# Altium schematic sheet Connectors.SchDoc(Connectors)
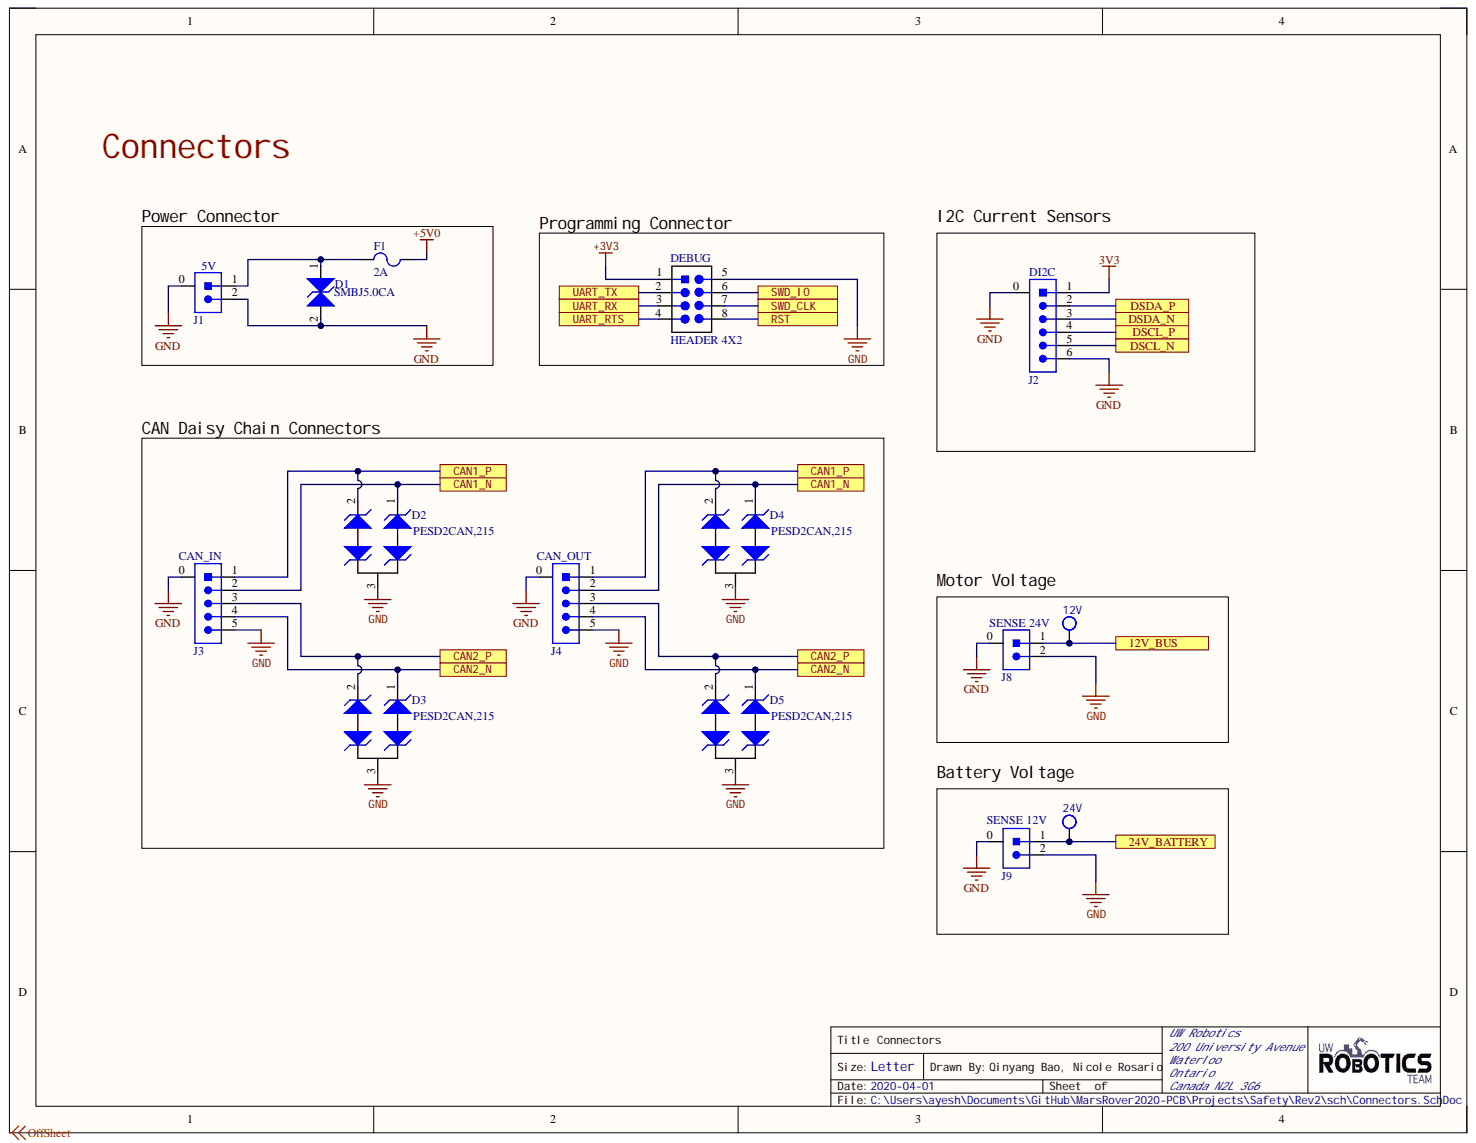
[diagram p0: Connectors.SchDoc]

## Parts
12V  TESTPOINT_LOOP  [TESTPOINT_LOOP]  (value not drawn)
24V  TESTPOINT_LOOP  [TESTPOINT_LOOP]  (value not drawn)
D1  Manufacturer Part Number 1: SMBJ5.0CA  [TVS_DIODE_BIDIRECTIONAL]  (hidden comment: TVS_DIODE_BIDIRECTIONAL)
D2  Manufacturer Part Number: PESD2CAN,215  [NXP-SOT323-3]  (hidden comment: TVS_DIODE_CAN)
D3  Manufacturer Part Number: PESD2CAN,215  [NXP-SOT323-3]  (hidden comment: TVS_DIODE_CAN)
D4  Manufacturer Part Number: PESD2CAN,215  [NXP-SOT323-3]  (hidden comment: TVS_DIODE_CAN)
D5  Manufacturer Part Number: PESD2CAN,215  [NXP-SOT323-3]  (hidden comment: TVS_DIODE_CAN)
DEBUG  HEADER 4X2  [HEADER_2X4]
F1  Current Rating: 2A  [FUSE_0603]  (hidden comment: FUSE_2A_0603)
J1  5V  [MOLEX-5024940270]
J2  DI2C  [MOLEX-5024940670]
J3  CAN_IN  [MOLEX-5025850570]
J4  CAN_OUT  [MOLEX-5025850570]
J8  SENSE 24V  [MOLEX-5024940270]
J9  SENSE 12V  [MOLEX-5024940270]

## Ports
12V_BUS, 24V_BATTERY, CAN1_N, CAN1_P, CAN2_N, CAN2_P, DSCL_N, DSCL_P, DSDA_N, DSDA_P, SWD_CLK, SWD_IO, UART_RTS, UART_RX, UART_TX, ~{RST}

## Nets
3V3: DEBUG.1 J2.1
+5V0: F1.2
GND: D1.2 D2.3 D3.3 D4.3 D5.3 DEBUG.5 J1.0 J1.2 J2.0 J2.6 J3.0 J3.5 J4.0 J4.5 J8.0 J8.2 J9.0 J9.2
12V_BUS: 12V.1 J8.1 ; port 12V_BUS
24V_BATTERY: 24V.1 J9.1 ; port 24V_BATTERY
~{RST}: DEBUG.8 ; port ~{RST}
CAN1_N: D2.1 D4.1 J3.2 J4.2 ; port CAN1_N
CAN1_P: D2.2 D4.2 J3.1 J4.1 ; port CAN1_P
CAN2_N: D3.1 D5.1 J3.4 J4.4 ; port CAN2_N
CAN2_P: D3.2 D5.2 J3.3 J4.3 ; port CAN2_P
DSCL_N: J2.5 ; port DSCL_N
DSCL_P: J2.4 ; port DSCL_P
DSDA_N: J2.3 ; port DSDA_N
DSDA_P: J2.2 ; port DSDA_P
SWD_CLK: DEBUG.7 ; port SWD_CLK
SWD_IO: DEBUG.6 ; port SWD_IO
UART_RTS: DEBUG.4 ; port UART_RTS
UART_RX: DEBUG.3 ; port UART_RX
UART_TX: DEBUG.2 ; port UART_TX
NetD1_1: D1.1 F1.1 J1.1
OffSheet:

## Library data of the parts
12V 24V = TESTPOINT_LOOP: Loop testpoint through-hole pad; Manufacturer: Keystone Electronics; Manufacturer Part Number 1: 5002; Component Kind: Standard; Supplier 1: Digi-Key; Supplier Part Number 1: 36-5002-ND; Library Name: UWRT_Schematic_Library_2020.SchLib
D1 = TVS_DIODE_BIDIRECTIONAL: TVS DIODE 5V 9.2V DO214AA; Manufacturer: Nexperia USA Inc.; Manufacturer 1: Littelfuse; Breakdown Voltage: 6.4V; Element Configuration: Single; ESD Protection: Yes; Leakage Current: 1.6mA; Max Breakdown Voltage: 7V; Max Power Dissipation: 5W; Max Surge Current: 65.3A; Number of Bidirectional Channels: 1; Peak Pulse Power: 600W; Power Line Protection: No; Test Current: 10mA; Case/Package: SMB; Clamping Voltage: 9.2V; Component Kind: Standard; ComponentLink1Description: Datasheet; ComponentLink1URL: https://www.littelfuse.com/~/media/electronics/datasheets/tvs_diodes/littelfuse_tvs_diode_smbj_datasheet.pdf.pdf; Composition: Zener; Direction: Bidirectional; Height: 0.096inch; Lead Free: Lead Free; Length: 0.179inch; Max Operating Temperature: 150°C; Max Reverse Leakage Current: 1.6mA; Min Breakdown Voltage: 6.4V; Min Operating Temperature: -65°C; Mount: Surface Mount; MPN: SMBJ5.0CA; Number of Channels: 1; Number of Elements: 1; Number of Pins: 2; Operating Supply Voltage: 5V; Package: SC-70, SOT-323; Package Quantity: 3000; Packaging: Tape and Reel; Peak Pulse Current: 65.3A; Polarity: Bidirectional; Power Dissipation: 600W; Pricing 1: 1=0.39000, 10=0.31300, 25=0.28640, 100=0.17980, 250=0.17784, 500=0.16650, 1000=0.11322 (USD); Radiation Hardening: No; REACH SVHC: No SVHC; Reverse Breakdown Voltage: 6.4V; Reverse Standoff Voltage: 5V; Stock 1: 23233; Supplier 1: Digi-Key; Supplier Part Number 1: SMBJ5.0CALFCT-ND; Termination: SMD/SMT; Voltage Rating (DC): 5V; Width: 0.155inch; Library Name: UWRT_Schematic_Library_2020.SchLib
D2-D5 = TVS_DIODE_CAN: TVS DIODE 24V 41V SOT323-3; Manufacturer: Nexperia USA Inc.; Component Kind: Standard; ComponentLink1Description: Datasheet; ComponentLink1URL: https://assets.nexperia.com/documents/data-sheet/PESD1CAN-U.pdf; Package: SC-70, SOT-323; Supplier 1: Digi-Key; Supplier Part Number 1: 1727-3891-1-ND; Library Name: UWRT_Schematic_Library_2020.SchLib
DEBUG = HEADER 4X2: CONN HEADER VERT 8POS 2.54MM; Manufacturer 1: Harwin Inc.; Manufacturer Part Number 1: M20-9980445; Component Kind: Standard; Signal Integrity: Connector; Supplier 1: Digi-Key; Supplier Part Number 1: 952-2122-ND; Library Name: UWRT_Schematic_Library_2020.SchLib
F1 = FUSE_2A_0603: FUSE SMD 2A 32V; Component Kind: Standard; ComponentLink1Description: https://datasheet.ciiva.com/303/467-303400.pdf?src-supplier=Digi-Key; ComponentLink1URL: https://datasheet.ciiva.com/303/467-303400.pdf?src-supplier=Digi-Key; ComponentLink2Description: http://datasheet.octopart.com/0467002.NRHF-Littelfuse-datasheet-32023482.pdf?src-supplier=Future+Electronics; ComponentLink2URL: http://datasheet.octopart.com/0467002.NRHF-Littelfuse-datasheet-32023482.pdf?src-supplier=Future+Electronics; ComponentLink3Description: http://datasheet.octopart.com/0467002.NRHF-Littelfuse-datasheet-136509808.pdf?src-supplier=Future+Electronics; ComponentLink3URL: http://datasheet.octopart.com/0467002.NRHF-Littelfuse-datasheet-136509808.pdf?src-supplier=Future+Electronics; Mount: Surface Mount; Supplier 1: Digi-Key; Supplier Packaging 1: Cut Tape; Supplier Part Number 1: F7132CT-ND; Voltage Rating (AC): 32V; Voltage Rating (DC): 32V; Library Name: UWRT_Schematic_Library_2020.SchLib
J1 J8 J9 = CON_2M_SM_RA: CONN HEADER SMD RIGHT ANGLE 2MM PITCH; Manufacturer 1: Molex; Manufacturer Part Number 1: 5024940270; Connector Type: Receptacle,Forked; Number of Positions: 2; Component Kind: Standard; ComponentLink1Description: https://www.molex.com/pdm_docs/as/AS-502439-002-001.pdf?src-supplier=Digi-Key; ComponentLink1URL: https://www.molex.com/pdm_docs/as/AS-502439-002-001.pdf?src-supplier=Digi-Key; Contact Plating: Tin; Fastening Type: Latch; Flammability Rating: UL94 V-0; Insulation Color: Natural; Insulation Material: Resin; Max Operating Temperature: 105°C; Min Operating Temperature: -40°C; Mount: Surface Mount; Number of Rows: 1; Packaging: Tape and Reel; Pricing 1: 1=0.53000, 10=0.49400, 25=0.44440, 50=0.39500, 100=0.37850, 250=0.34556 (USD); RoHS: No; Stock 1: 3397; Supplier 1: Digi-Key; Supplier Part Number 1: WM15810CT-ND; Termination: Solder; Library Name: UWRT_Schematic_Library_2020.SchLib
J2 = CON_6M_SM_RA: CONN HEADER SMD RIGHT ANGLE 2MM PITCH; Manufacturer 1: Molex; Manufacturer Part Number 1: 502494-0670; Connector Type: Receptacle,Forked; Current Rating: 3A; Gender: Receptacle; Glow Wire Compliant: Non-compliant; Insulation Resistance: 1GR; Max Voltage Rating (AC): 250V; Number of Circuits: 6; Color: Natural; Component Kind: Standard; ComponentLink1Description: https://datasheet.ciiva.com/755/5024940670-sd-755844.pdf?src-supplier=Digi-Key; ComponentLink1URL: https://datasheet.ciiva.com/755/5024940670-sd-755844.pdf?src-supplier=Digi-Key; ComponentLink2Description: https://datasheet.ciiva.com/24467/101355024940670-pcb-receptacles-24467588.pdf?src-supplier=Onlinecomponents.com; ComponentLink2URL: https://datasheet.ciiva.com/24467/101355024940670-pcb-receptacles-24467588.pdf?src-supplier=Onlinecomponents.com; ComponentLink3Description: https://datasheet.ciiva.com/16656/5024940670-pcb-receptacles-16656439.pdf?src-supplier=Avnet+Europe; ComponentLink3URL: https://datasheet.ciiva.com/16656/5024940670-pcb-receptacles-16656439.pdf?src-supplier=Avnet+Europe; ComponentLink4Description: https://datasheet.ciiva.com/7436/1630854-7436283.pdf?src-supplier=Newark; ComponentLink4URL: https://datasheet.ciiva.com/7436/1630854-7436283.pdf?src-supplier=Newark; ComponentLink5Description: https://datasheet.ciiva.com/5157/5024940670-pcb-receptacles-179322-5157180.pdf?src-supplier=Mouser; ComponentLink5URL: https://datasheet.ciiva.com/5157/5024940670-pcb-receptacles-179322-5157180.pdf?src-supplier=Mouser; ComponentLink6Description: https://datasheet.ciiva.com/7917/1630854-7917014.pdf?src-supplier=Element14; ComponentLink6URL: https://datasheet.ciiva.com/7917/1630854-7917014.pdf?src-supplier=Element14; ComponentLink7Description: https://datasheet.ciiva.com/30242/101355024940670-pcb-receptacles-30242550.pdf?src-supplier=Onlinecomponents.com; ComponentLink7URL: https://datasheet.ciiva.com/30242/101355024940670-pcb-receptacles-30242550.pdf?src-supplier=Onlinecomponents.com; ComponentLink8Description: https://datasheet.ciiva.com/755/5024940670-sd-755789.pdf?src-supplier=Digi-Key; ComponentLink8URL: https://datasheet.ciiva.com/755/5024940670-sd-755789.pdf?src-supplier=Digi-Key; Contact Gender: Female; Contact Material: Copper Alloy; Contact Plating: Tin; Depth: 6.85mm; Fastening Type: Latch; Flammability Rating: UL94 V-0; Housing Color: Natural; Housing Material: PBT; Length: 16mm; Material: Polybutylene; Max Operating Temperature: 85°C; Max Voltage Rating (DC): 250V; Min Operating Temperature: -25°C; Mount: Surface Mount; Number of Contacts: 6; Number of Positions: 6; Number of Rows: 1; Orientation: Right Angle; Package Quantity: 950; Packaging: Tape and Reel; Pitch: 2mm; Pricing 1: 1=0.81000, 10=0.70800, 25=0.66680, 50=0.63880, 100=0.61110, 250=0.55552 (USD); Radiation Hardening: No; REACH SVHC: Unknown; RoHS: Yes; Stock 1: 7093; Supplier 1: Digi-Key; Supplier Part Number 1: WM2283CT-ND; Termination: Solder; Voltage Rating: 250V; Library Name: UWRT_Schematic_Library_2020.SchLib
J3 J4 = CON_5M_SM_RA: CONN HEADER SMD RIGHT ANGLE 1.5MM PITCH; Manufacturer 1: Molex; Manufacturer Part Number 1: 5025850570; Connector Type: Receptacle,Center Strip Contact; Current Rating: 2A; Number of Circuits: 5; Component Kind: Standard; Mount: Surface Mount; Number of Contacts: 5; Number of Positions: 5; Number of Rows: 1; Orientation: Right Angle; RoHS: Yes; Stock 1: 2875; Supplier 1: Digi-Key; Supplier Part Number 1: WM14469CT-ND; Termination: Solder; Library Name: UWRT_Schematic_Library_2020.SchLib
